# Altium schematic sheet Debug_Circuit.SchDoc(Debug_Circuit)
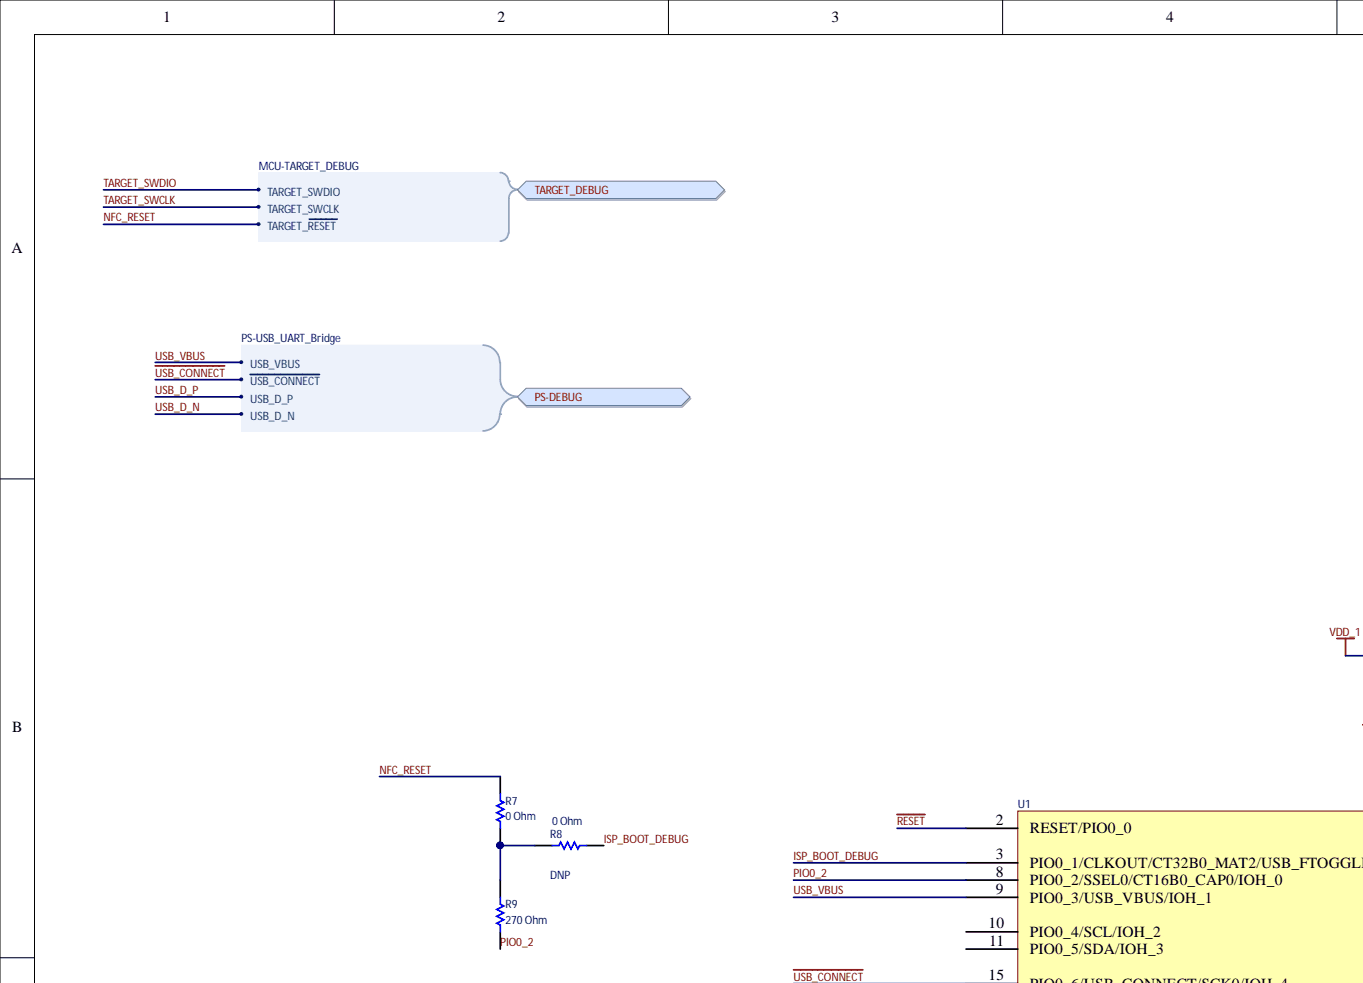
[diagram p0: Debug_Circuit.SchDoc, top left]
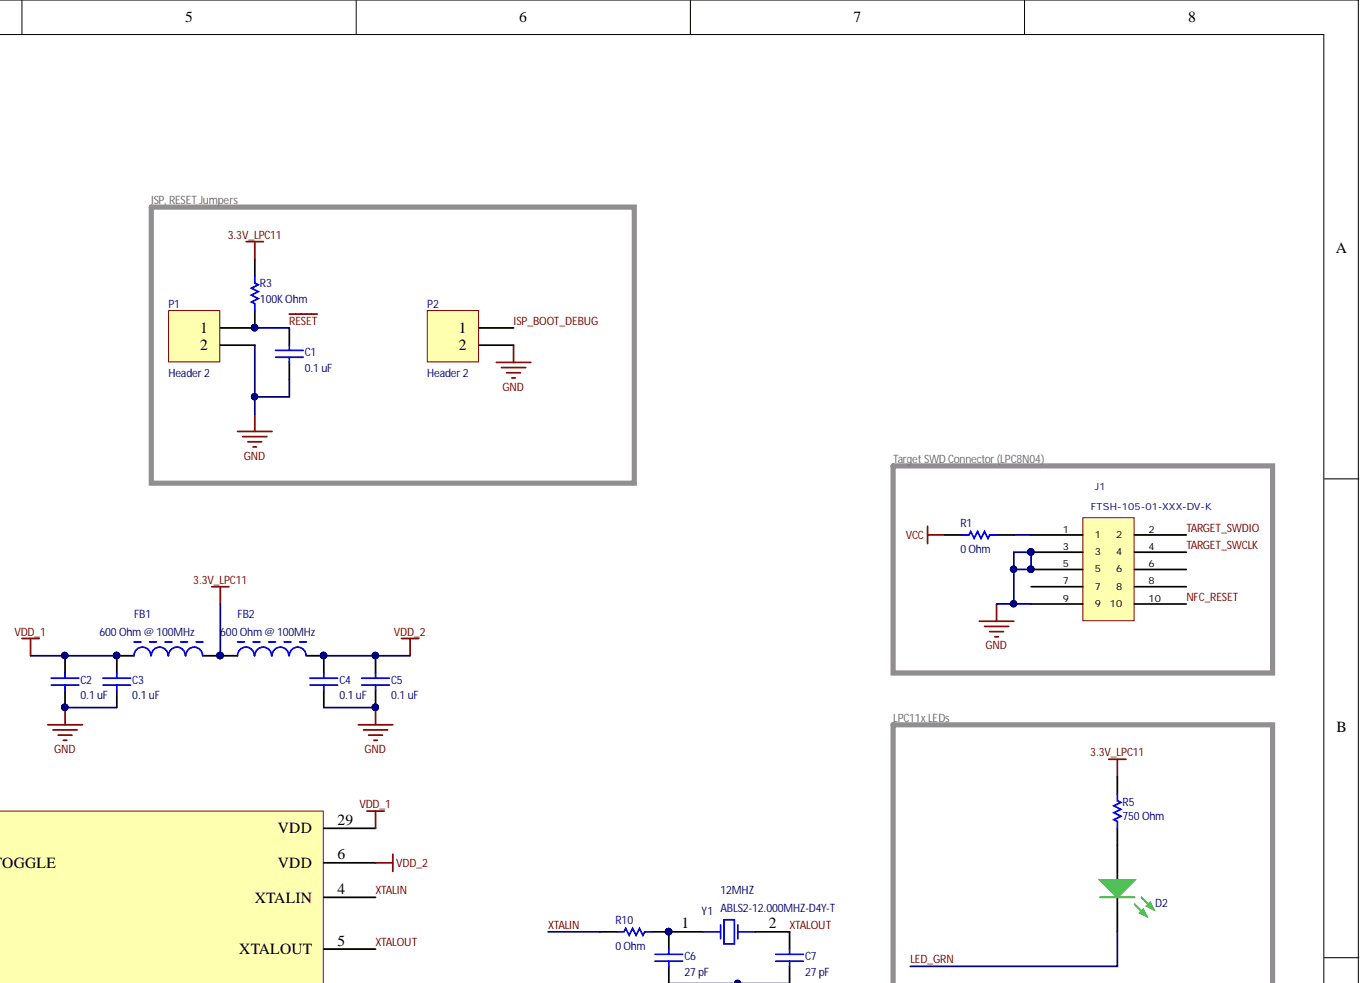
[diagram p1: Debug_Circuit.SchDoc, top right]
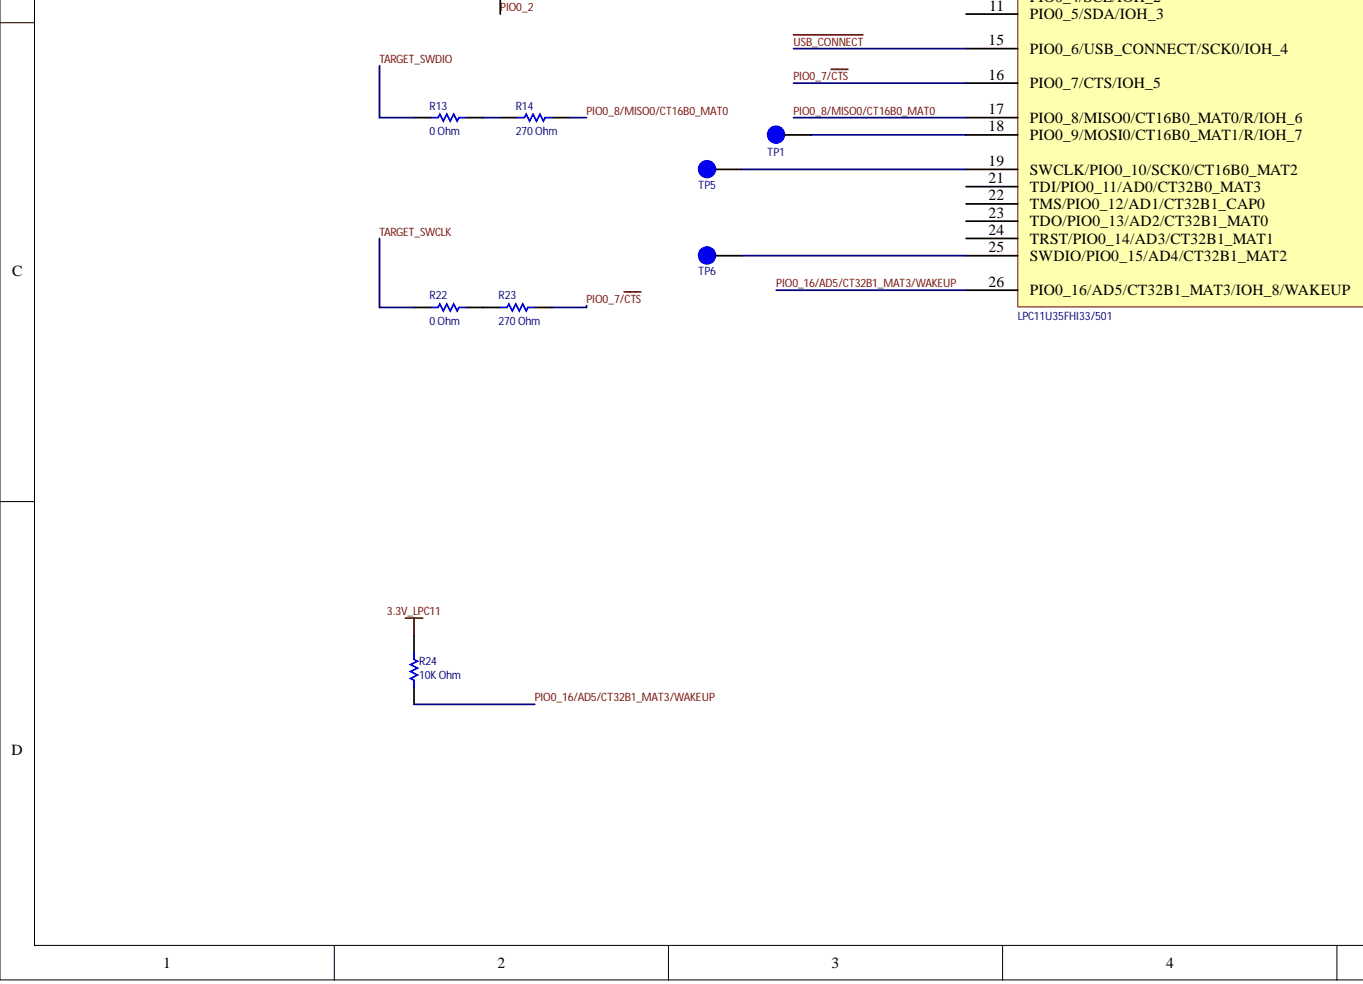
[diagram p2: Debug_Circuit.SchDoc, bottom left]
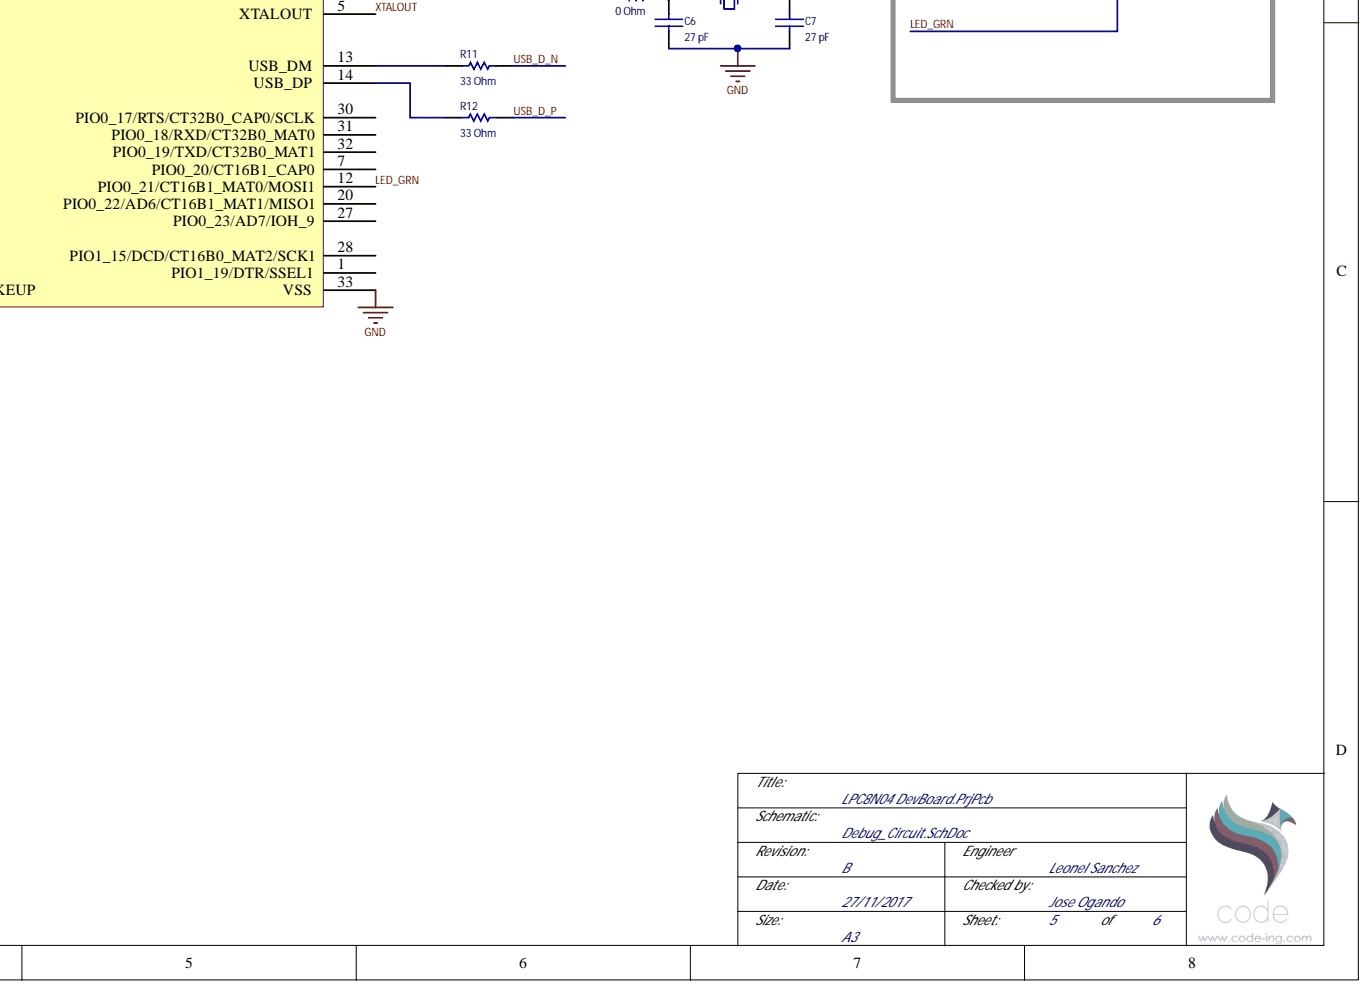
[diagram p3: Debug_Circuit.SchDoc, bottom right]

## Parts
C1  Capacitance: 0.1 uF  [CAPC1005]  (hidden comment: CC0402KRX7R7BB104)
C2  CC0402KRX7R7BB104  [CAPC1005]  (value not drawn)
C3  Capacitance: 0.1 uF  [CAPC1005]  (hidden comment: CC0402KRX7R7BB104)
C4  CC0402KRX7R7BB104  [CAPC1005]  (value not drawn)
C5  Capacitance: 0.1 uF  [CAPC1005]  (hidden comment: CC0402KRX7R7BB104)
C6  Capacitance: 27 pF  [CAPC1005X55X30NL05T10]  (hidden comment: C0402C270J5GACTU)
C7  Capacitance: 27 pF  [CAPC1005X55X30NL05T10]  (hidden comment: C0402C270J5GACTU)
D2  LTST-C190KGKT  [1.6X.8 SMT LED 0603]  (value not drawn)
FB1  value 600 Ohm @ 100MHz  [MURA-BLM15-CHIP-2_V]  (hidden comment: BLM15AG601SN1D)
FB2  value 600 Ohm @ 100MHz  [MURA-BLM15-CHIP-2_V]  (hidden comment: BLM15AG601SN1D)
J1  FTSH-105-01-XXX-DV-K  [FTSH-105-01-XXX-DV-K]
P1  Header 2  [HDR1X2 - 0.1'']
P2  Header 2  [HDR1X2 - 0.1'']
R1  value 0 Ohm  [RESC0402(1005)_N]  (hidden comment: Jumper 0402(1005))
R3  value 100K Ohm  [RESC0402(1005)_N]  (hidden comment: 100K 5% 0402(1005))
R5  value 750 Ohm  [RESC0402(1005)_N]  (hidden comment: 750R 1% 0402(1005))
R7  value 0 Ohm  [RESC0402(1005)_N]  (hidden comment: Jumper 0402(1005))
R8  value 0 Ohm  Indications: DNP  [RESC0402(1005)_N]  (hidden comment: Jumper 0402(1005))
R9  value 270 Ohm  [RESC0402(1005)_N]  (hidden comment: 270R 1% 0402(1005))
R10  value 0 Ohm  [RESC0402(1005)_N]  (hidden comment: Jumper 0402(1005))
R11  value 33 Ohm  [RESC0402(1005)_N]  (hidden comment: 33R 5% 0402(1005))
R12  value 33 Ohm  [RESC0402(1005)_N]  (hidden comment: 33R 5% 0402(1005))
R13  value 0 Ohm  [RESC0402(1005)_N]  (hidden comment: Jumper 0402(1005))
R14  value 270 Ohm  [RESC0402(1005)_N]  (hidden comment: 270R 1% 0402(1005))
R22  value 0 Ohm  [RESC0402(1005)_N]  (hidden comment: Jumper 0402(1005))
R23  value 270 Ohm  [RESC0402(1005)_N]  (hidden comment: 270R 1% 0402(1005))
R24  value 10K Ohm  [RESC0402(1005)_N]  (hidden comment: 10K 5% 0402(1005))
TP1  TP  [SMT Test Point]  (no BOM)
TP5  TP  [SMT Test Point]  (no BOM)
TP6  TP  [SMT Test Point]  (no BOM)
U1  LPC11U35FHI33/501  [HVQFN33 5x5x0.85]
Y1  ABLS2-12.000MHZ-D4Y-T  value 12MHZ  [2-LEAD 11.4X4.8]

## Ports
PS-DEBUG, PS-DEBUG.USB_D_N, PS-DEBUG.USB_D_P, PS-DEBUG.USB_VBUS, PS-DEBUG.~{USB_CONNECT}, TARGET_DEBUG, TARGET_DEBUG.TARGET_SWCLK, TARGET_DEBUG.TARGET_SWDIO, TARGET_DEBUG.TARGET_~{RESET}

## Nets
3.3V_LPC11: FB1.2 FB2.1 R3.2 R5.2 R24.1
GND: C1.1 C2.1 C3.1 C4.1 C5.1 C6.1 C7.1 J1.3 J1.5 J1.9 P1.2 P2.2 U1.33(VSS)
VCC: R1.1
VDD_1: C2.2 C3.2 FB1.1 U1.29(VDD)
VDD_2: C4.2 C5.2 FB2.2 U1.6(VDD)
ISP_BOOT_DEBUG: P2.1 R8.1 U1.3
LED_GRN: D2.2 U1.12
NFC_RESET: J1.10 R7.2 ; port TARGET_DEBUG
PIO0_2: R9.2 U1.8
PIO0_7/~{CTS}: R23.1 U1.16
PIO0_8/MISO0/CT16B0_MAT0: R14.1 U1.17
PIO0_16/AD5/CT32B1_MAT3/WAKEUP: R24.2 U1.26
~{RESET}: C1.2 P1.1 R3.1 U1.2(RESET/PIO0_0)
TARGET_SWCLK: J1.4 R22.1 ; port TARGET_DEBUG
TARGET_SWDIO: J1.2 R13.1 ; port TARGET_DEBUG
~{USB_CONNECT}: U1.15 ; port PS-DEBUG
USB_D_N: R11.2 ; port PS-DEBUG
USB_D_P: R12.2 ; port PS-DEBUG
USB_VBUS: U1.9 ; port PS-DEBUG
XTALIN: R10.1 U1.4(XTALIN)
XTALOUT: C7.2 U1.5(XTALOUT) Y1.2
NetC6_2: C6.2 R10.2 Y1.1
NetD2_1: D2.1 R5.1
NetJ1_1: J1.1 R1.2
NetR7_1: R7.1 R8.2 R9.1
NetR11_1: R11.1 U1.13(USB_DM)
NetR12_1: R12.1 U1.14(USB_DP)
NetR13_2: R13.2 R14.2
NetR22_2: R22.2 R23.2
NetTP1_1: TP1.1 U1.18
NetTP5_1: TP5.1 U1.19(SWCLK/PIO0_10/SCK0/CT16B0_MAT2)
NetTP6_1: TP6.1 U1.25(SWDIO/PIO0_15/AD4/CT32B1_MAT2)

Not connected: J1 6 7 8; U1 1(PIO1_19/DTR/SSEL1) 7 10 11 20 21(TDI/PIO0_11/AD0/CT32B0_MAT3) 22(TMS/PIO0_12/AD1/CT32B1_CAP0) 23(TDO/PIO0_13/AD2/CT32B1_MAT0) 24(TRST/PIO0_14/AD3/CT32B1_MAT1) 27 28(PIO1_15/DCD/CT16B0_MAT2/SCK1) 30 31 32

## Library data of the parts
C1-C5 = CMP-0001-00074-1: Capacitor, Yageo, Ceramic, 0.1uF 10% 16V 0402 (1005) X7R; Polarity: Non-Polarized; Tolerance: 10 %; Technology: Ceramic; Status: Production; Rated Voltage: 16 V; Mounting Technology: Surface Mount; Library Name: Altium Content Vault; Dielectric: X7R; Component Kind: Standard; ClassName: Board Template Device; Category: Capacitor; Publisher: Altium
  C2 C4: Capacitance: 0.1 uF
C6 C7 = CMP-2008-03286-3: Chip Capacitor, 27 pF, +/- 5%, 50 V, -55 to 125 degC, 0402 (1005 Metric), RoHS, Tape and Reel; Voltage Rating: 50 V; Width: 0.5 mm; Tolerance: 5%; RoHSCompliant: True; REACH SVHC: No SVHC; PartNumber: C0402C270J5GACTU; Packaging: Tape and Reel; Package Quantity: 10000; PackageDescription: 2-Pin Surface Mount Device, Body 1 x 0.5 mm; Mounting Technology: Surface Mount; Min Operating Temperature: -55 degC; Max Operating Temperature: 125 degC; Library Name: Altium Content Vault; Length: 1 mm; Height: 0.5 mm; ComponentLink1URL: http://www.kemet.com/docfinder?Partnumber=C0402C270J5GACTU; ComponentLink1Description: Datasheet; Component Kind: Standard; Case Code (Metric): 1005; Case Code (Imperial): 0402; Case/Package: 0402
D2 = LTST-C190KGKT: LED GREEN CLEAR 0603 SMD; Manufacturer: Lite-On Inc.; Manufacturer part #: LTST-C190KGKT; Creation date: 04-Jul-2017; Component Kind: Standard; Library Name: LED Indication - Discrete.IntLib; Created by: eteofilo
FB1 FB2 = CMP-0686-00187-3: Chip Ferrite Bead for General Use, 600 Ohm, 300 mA, -55 to 125 degC, 1 x 0.5 x 0.55 mm SMD, Tape and Reel; Manufacturer: Murata; Rated Current: 300 mA; Impedance (at 100 MHz): 600 Ohm +/- 25%; DC Resistance: 0.52 Ohm Max.; PartNumber: BLM15AG601SN1D; Packing: Tape and Reel; PackageReference: BLM15-2; PackageDescription: 2-Pin Chip, Body 1 x 0.5 mm; Operating Temperature Range: -55 to 125 degC; Mounting Technology: Surface Mount; Library Name: Altium Content Vault; DatasheetVersion: 08/2013; ComponentLink3URL: http://www.murata.com/products/catalog/pdf/c31e.pdf; ComponentLink3Description: Package Specification; ComponentLink2URL: http://www.murata.com/products/catalog/pdf/c31e.pdf; ComponentLink2Description: Datasheet; ComponentLink1URL: http://www.murata.com/; ComponentLink1Description: Manufacturer URL; Component Kind: Standard
J1 = FTSH-105-01-XXX-DV-K: TERMINAL STRIP, .050"(1.27mm) PITCH, 10 PINS, KEYED; MANUFACTURER: SAMTEC; PCB DECAL: FTSH-105-01-XXX-DV-K; Library Name: FTSH-105-01-XXX-DV-K.IntLib; Component Kind: Standard; CREATED: November 30, 2016 - Wendy Xin
P1 P2 = Header 2: Header, 2-Pin; Signal Integrity: Connector; LatestRevisionNote: Re-released for DXP Platform.; LatestRevisionDate: 17-Jul-2002; Component Kind: Standard; Publisher: Altium Limited; Library Name: CODE Miscellaneous Connectors.IntLib
R1 R7 R8 R10 R13 R22 = CMP-1011-00001-1: Jumper 0402 (1005 Metric); Manufacturer Part #: RC1005J000CS; Tolerance: None; Case-Metric: 1005; Case-EIA: 0402; Technology: SMT; Power: None; Library Name: Altium Content Vault; Component Kind: Standard
R3 = CMP-1011-00122-1: 100K 0.063W 5% 0402 (1005 Metric)  SMD; Case-Metric: 1005; Case-EIA: 0402; Tolerance: 5 %; Technology: SMT; Power: 0.063 W; Library Name: Altium Content Vault; Component Kind: Standard
R5 = CMP-1011-00496-1: 750R 0.063W 1% 0402 (1005 Metric)  SMD; Case-Metric: 1005; Case-EIA: 0402; Tolerance: 1 %; Technology: SMT; Power: 0.063 W; Library Name: Altium Content Vault; Component Kind: Standard
R9 R14 R23 = CMP-1011-00444-1: 270R 0.063W 1% 0402 (1005 Metric)  SMD; Case-Metric: 1005; Case-EIA: 0402; Tolerance: 1 %; Technology: SMT; Power: 0.063 W; Library Name: Altium Content Vault; Component Kind: Standard
R11 R12 = CMP-1011-00038-1: 33R 0.063W 5% 0402 (1005 Metric)  SMD; Case-Metric: 1005; Case-EIA: 0402; Tolerance: 5 %; Technology: SMT; Power: 0.063 W; Library Name: Altium Content Vault; Component Kind: Standard
R24 = CMP-1011-00098-1: 10K 0.063W 5% 0402 (1005 Metric)  SMD; Case-Metric: 1005; Case-EIA: 0402; Tolerance: 5 %; Technology: SMT; Power: 0.063 W; Library Name: Altium Content Vault; Component Kind: Standard
TP1 TP5 TP6 = TP: PCB TEST POINT SMT; Library Name: PCB Test Points.IntLib; Creation date: 26-Apr-2012; Created by: gmoreno
U1 = LPC11U35FHI33/501: IC MCU 32BIT 64KB FLASH 33HVQFN; Manufacturer: NXP USA Inc.; Manufacturer part #: LPC11U35FHI33/501; Library Name: Embedded - Microcontrollers.IntLib; Creation date: 4-Jul-2017; Component Kind: Standard; Created by: eteofilo
Y1 = ABLS2-12.000MHZ-D4Y-T: CRYSTAL 12.0000MHZ 18PF SMD; Manufacturer: Abracon LLC; Manufacturer part #: ABLS2-12.000MHZ-D4Y-T; Library Name: Crystals.IntLib; Creation date: 06-Jul-2017; Component Kind: Standard; Created by: eteofilo
